# Altium schematic sheet Trap_PCB_V3.SchDoc
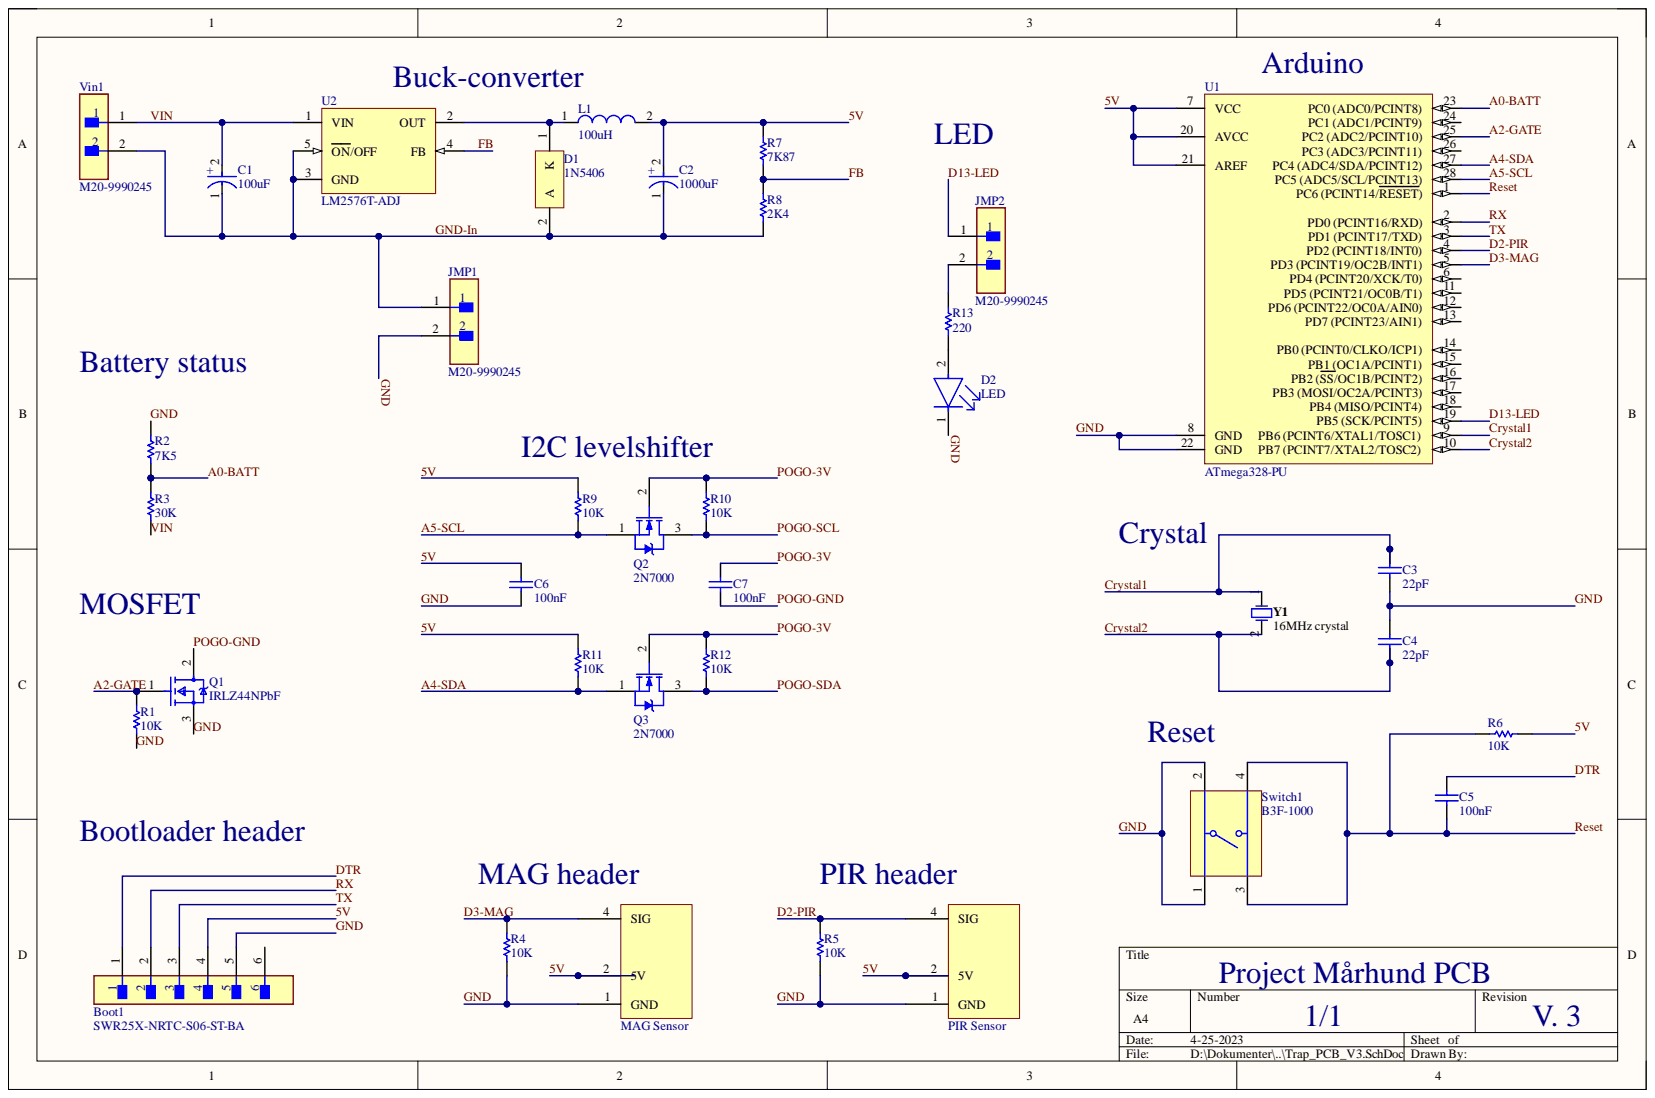
[diagram p0: Trap_PCB_V3.SchDoc]

## Parts
*  PIR Sensor  [PCBComponent_1 - duplicate2]  (value not drawn)
*?  MAG Sensor  [PCBComponent_1 - duplicate2]
Boot1  SWR25X-NRTC-S06-ST-BA  [FP-SWR25X-NRTC-S06-ST-BA-MFG]
C1  100uF  [FP-EEU-FR1H101B-MFG]
C2  1000uF  [FP-RAD-TH-D_10_0_5-L_12_5-MFG]
C3  22pF  [KEMT-C315-THT-2]
C4  22pF  [KEMT-C315-THT-2]
C5  100nF  [KEMT-C315-THT-2]
C6  100nF  [KEMT-C315-THT-2]
C7  100nF  [KEMT-C315-THT-2]
D1  1N5406  [ONSC-AXIAL_LEAD-2-267-05_V]
D2  LED  [FP-HLMP-1719-MFG]
JMP1  M20-9990245  [FP-M20-9990245-MFG]
JMP2  M20-9990245  [FP-M20-9990245-MFG]
L1  100uH  [WE-TI-LB]
Q1  IRLZ44NPbF  [FP-PG-TO220-3-MFG]
Q2  2N7000  [ONSC-TO-92-3-29-11]
Q3  2N7000  [ONSC-TO-92-3-29-11]
R1  10K  [OMTE-RES7X2.5-2]
R2  7K5  [OMTE-RES7X2.5-2]
R3  30K  [OMTE-RES7X2.5-2]
R4  10K  [OMTE-RES7X2.5-2]
R5  10K  [OMTE-RES7X2.5-2]
R6  10K  [OMTE-RES7X2.5-2]
R7  7K87  [OMTE-RES7X2.5-2]
R8  2K4  [OMTE-RES7X2.5-2]
R9  10K  [OMTE-RES7X2.5-2]
R10  10K  [OMTE-RES7X2.5-2]
R11  10K  [OMTE-RES7X2.5-2]
R12  10K  [OMTE-RES7X2.5-2]
R13  220  [OMTE-RES7X2.5-2]
Switch1  B3F-1000  [OMRON-B3F-1000-4_V]
U1  ATmega328-PU  [28P3]
U2  LM2576T-ADJ  [KC0005A]
Vin1  M20-9990245  [FP-M20-9990245-MFG]
Y1  16MHz crystal  [FOX-FOXSLF160-20]

## Nets
5V: *.2 *?.2 Boot1.4 C2.2 C6.2 L1.2 R6.1 R7.2 R9.1 R11.1 U1.7(VCC) U1.20(AVCC) U1.21(AREF)
A0-BATT: R2.1 R3.2 U1.23
A2-GATE: Q1.1 R1.2 U1.25
A4-SDA: Q3.1 R11.2 U1.27
A5-SCL: Q2.1 R9.2 U1.28(PC5_(ADC5/SCL/PCINT13))
Crystal1: C3.2 U1.9 Y1.1
Crystal2: C4.1 U1.10 Y1.2
D2-PIR: *.4 R5.2 U1.4(PD2_(PCINT18/INT0))
D3-MAG: *?.4 R4.2 U1.5(PD3_(PCINT19/OC2B/INT1))
D13-LED: JMP2.1 U1.19(PB5_(SCK/PCINT5))
DTR: Boot1.1 C5.2
FB: R7.1 R8.2 U2.4(FB)
GND: *.1 *?.1 Boot1.5 C3.1 C4.2 C6.1 D2.1 JMP1.2 Q1.3 R1.1 R2.2 R4.1 R5.1 Switch1.1 Switch1.2 U1.8(GND) U1.22(GND)
GND-In: C1.1 C2.1 D1.2 JMP1.1 R8.1 U2.3(GND) U2.5(ON/OFF) Vin1.2
POGO-3V: C7.1 Q2.2 Q3.2 R10.1 R12.1
POGO-GND: C7.2 Q1.2
POGO-SCL: Q2.3 R10.2
POGO-SDA: Q3.3 R12.2
Reset: C5.1 R6.2 Switch1.3 Switch1.4 U1.1(PC6_(PCINT14/RESET))
RX: Boot1.2 U1.2(PD0_(PCINT16/RXD))
TX: Boot1.3 U1.3(PD1_(PCINT17/TXD))
VIN: C1.2 R3.1 U2.1(VIN) Vin1.1
NetD1_1: D1.1 L1.1 U2.2(OUT)
NetD2_2: D2.2 R13.1
NetJMP2_2: JMP2.2 R13.2

Not connected: Boot1 6; U1 6(PD4_(PCINT20/XCK/T0)) 11(PD5_(PCINT21/OC0B/T1)) 12(PD6_(PCINT22/OC0A/AIN0)) 13(PD7_(PCINT23/AIN1)) 14(PB0_(PCINT0/CLKO/ICP1)) 15(PB1_(OC1A/PCINT1)) 16(PB2_(SS/OC1B/PCINT2)) 17(PB3_(MOSI/OC2A/PCINT3)) 18(PB4_(MISO/PCINT4)) 24(PC1_(ADC1/PCINT9)) 26(PC3_(ADC3/PCINT11))

## Library data of the parts
*? = PIR Sensor: Component Kind: Standard; Library Name: Trap_PCB_V2.SchLib
Boot1 = CMP-25680-000010-1: CONN HEADER VERT 6POS 2.54MM; Manufacturer: Sullins; Conn Gender: Male; Conn Orientation: Straight; Current Rating: 3A; Number Of Contacts: 6; Voltage Rating: 250V; Automotive: No; Category: Conn; Component Kind: Standard; ComponentLink2Description: Datasheet; ComponentLink2URL: https://drawings-pdf.s3.amazonaws.com/11633.pdf; Contact Material: Tin,Nickel; Device Class L1: Connectors; Device Class L2: Headers and Wire Housings; Device Class L3: unset; Digikey Description: CONN HEADER VERT 6POS 2.54MM; Height: 11.7mm; Lead Free: Yes; Library Name: Altium Content Vault; Manufacturer Part Number: SWR25X-NRTC-S06-ST-BA; Number Of Rows: 1; Octopart: https://octopart.com/swr25x-nrtc-s06-st-ba-sullins-20912730; Package: SWR25X-NRTC-S06-ST-BA; Pitch: 2.54mm; RoHS: TRUE; Temperature: -25°C to +85°C; Temperature Range High: +85°C; Temperature Range Low: -25°C
C1 = CMP-05427-000020-1: CAP ALUM 100UF 20% 50V RADIAL; ESR (Equivalent Series Resistance): 61mR; Lead Diameter: 0.6mm; Life (Hours): 6000Hour; Ripple Current: 870mA; Capacitance: 100uF; Case/Package: Radial; Component Kind: Standard; Contact Plating: Tin; Diameter: 8mm; Features: General Purpose; Height: 11.5mm; Height - Seated (Max): 0.512inch; Impedance: 61mR; Lead Free: Lead Free; Lead Pitch: 5mm; Length: 11.5mm; Library Name: Altium Content Vault; Max Operating Temperature: 105°C; Min Operating Temperature: -40°C; Mount: Through Hole; Package Quantity: 1; Packaging: Tape and Reel; Radiation Hardening: No; REACH SVHC: No SVHC; RoHS Compliant: Yes; Series: FR; Termination: Radial; Tolerance: 20%; Voltage Rating: 50V; Voltage Rating (DC): 50V
C2 = CMP-05427-001280-1: Aluminum Electrolytic Capacitors (Radial Lead Type) AEC-Q200 Qualified 1000uF ±20% 6.3V; Capacitance: 1mF; Dielectric Material: Aluminium; ESR (Equivalent Series Resistance): 90mR; Lead Diameter: 0.6mm; Leakage Current: 3uA; Life (Hours): 3000Hour; Polarity: Polar; Ripple Current: 755mA; Case/Package: Radial; Component Kind: Standard; Construction: RADIAL; Contact Plating: Tin; Diameter: 10mm; Height: 12.5mm; Height - Seated (Max): 0.492inch; Impedance: 90mR; Lead Free: Lead Free; Lead Pitch: 5mm; Length: 12.5mm; Library Name: Altium Content Vault; Max Operating Temperature: 105°C; Min Operating Temperature: -55°C; Mount: Through Hole; Number of Pins: 2; Package Quantity: 200; Packaging: Bulk; Radiation Hardening: No; Ratings: AEC-Q200; REACH SVHC: No SVHC; Ripple Current (AC): 755mA; RoHS Compliant: Yes; Series: FC; Size: 12.5mm; Termination: Radial; Tolerance: 20%; Voltage: 6.3V; Voltage Rating: 6.3V; Voltage Rating (DC): 6.3V
C3-C7 = CMP-1664-00008-1: Capacitor, Ceramic, 22 pF, +/-10 %, 100 V, -55 to 125 degC, 2-Pin THD, RoHS, Bulk; Case\Package: TO-220; Max Input Voltage: 40 V; Max Ouput Current: 1.5 A; Min Input Voltage: 4.2 V; Output Voltage: 1.2 V; Outputs: 1; Component Kind: Standard; ComponentLink1Description: Manufacturer URL; ComponentLink1URL: http://www.kemet.com/; ComponentLink2Description: Datasheet; ComponentLink2URL: http://capacitoredge.kemet.com/capedge2/DataSheet/Datasheet-C315C220K1G5TA.pdf?pn=C315C220K1G5TA{BULK}; DatasheetVersion: Aug-16; Height: 9.28 mm; Length: 10.28 mm; Library Name: Altium Content Vault; Max Operating Temperature: 125 degC; Min Operating Temperature: 0 degC; Mount: Through Hole; Output Current: 1.5 A; Package Quantity: 50; PackageDescription: 2-Pin Through Hole Device, Body 3.81 x 2.54 mm; PackageReference: C315-THT-2; Packaging: Rail/Tube; Pins: 3; Radiation Hardening: No; REACH SVHC: No SVHC; RoHSCompliant: true; Weight: 0.08113 oz; Width: 4.82 mm
D1 = CMP-0902-00090-1: Standard Recovery Rectifier, 2-Pin Axial_Lead, Pb-Free, Bulk Box; Manufacturer: On Semiconductor; IFSM Max (A): 200; IO(rec) Max (A): 3; VRRM Max (V): 600; Component Kind: Standard; ComponentLink1Description: Manufacturer URL; ComponentLink1URL: http://www.onsemi.com/; ComponentLink2Description: Datasheet; ComponentLink2URL: http://www.onsemi.com/pub_link/Collateral/1N5400-D.PDF; ComponentLink3Description: Package Specification; ComponentLink3URL: http://www.onsemi.com/pub_link/Collateral/267-05.PDF; DatasheetVersion: Rev. 10; Library Name: Altium Content Vault; Mounting Technology: Through Hole; PackageDescription: 2-Pin, Axial Lead, Diameter 5.05 mm; PackageReference: AXIAL_LEAD-2-267-05; PackageVersion: Rev. G, 06/2000; Packing: Bulk Box; PartNumber: 1N5406; RoHS: TRUE; Type: Standard Recovery
D2 = CMP-2000-06410-2: LED YELLOW DIFFUSED T-1 T/H; Manufacturer: Broadcom; Forward Current: 2mA; Forward Voltage: 1.8V; Led Orientation: Straight; Luminous Intensity: 2.1mcd; Power Dissipation: 17.5mW; Reverse Voltage: 5V; Viewing Angle: 50°; Wavelength: 585nm; Automotive: No; Category: Diode; Colour: Yellow; Component Kind: Standard; ComponentLink2Description: Datasheet; ComponentLink2URL: https://docs.broadcom.com/docs/AV02-1557EN; Device Class L1: Optoelectronics; Device Class L2: LEDs; Device Class L3: unset; Digikey Description: LED YELLOW DIFFUSED T-1 T/H; Lead Free: Yes; Library Name: Altium Content Vault; Manufacturer Part Number: HLMP-1719; Mouser Description: Standard LEDs - Through Hole Yellow Diffused 585nm 2.1mcd; Octopart: https://octopart.com/hlmp-1719-broadcom-71130405; Package: 0; RoHS: TRUE; Temperature: -40°C to +100°C; Temperature Range High: +100°C; Temperature Range Low: -40°C
JMP1 JMP2 Vin1 = CMP-15831-000105-1: CONN HEADER VERT 2POS 2.54MM; Connector Type: Male Pin; Contact Resistance: 25mR; Current: 3A; Gender: Male; Insulation Resistance: 10GR; Mated Stacking Heights: 16.54mm; Mating Cycles: 300; Number of Contacts: 2; Voltage: 50V; Voltage Rating (AC): 750V; Case/Package: SIL; Color: Black; Component Kind: Standard; Contact Finish - Mating: GOLD; Contact Material: Brass; Contact Mating Length: 0.24inch; Contact Plating: Gold; Contact Shape: Square; Contact Type: Male Pin; Current Rating: 3A; Depth: 2.54mm; Fastening Type: Pull; Flammability Rating: UL94 V-0; Height: 0.12inch; Housing Color: Black; Housing Material: Nylon; Insulation Color: Black; Insulation Material: Nylon; Lead Pitch: 2.54mm; Length: 5.08mm; Library Name: Altium Content Vault; Max Current Rating: 3A; Max Operating Temperature: 105°C; Min Operating Temperature: -40°C; Mount: Through Hole; Number of Poles: 2; Number of Positions: 2; Number of Rows: 1; Orientation: Straight; Package Quantity: 1000; Packaging: Bulk; Pitch: 2.54mm; Radiation Hardening: No; Reach SVHC Compliant: Yes; Series: M20; Termination: Solder; Weight: 0.25lb; Width: 0.25inch; Working Voltage: 750V
L1 = CMP-0225-00065-1: Radial Leaded Wire Wound Inductor WE-TI, L = 100 µH; Inductance: 100 ÂµH; Component Kind: Standard; ComponentLink1Description: Manufacturer Link; ComponentLink1URL: http://www.we-online.com; ComponentLink2Description: Datasheet; ComponentLink2URL: http://www.we-online.de/katalog/media/pdf/744743101.pdf; DatasheetVersion: ver 3, Nov-2004; Library Name: Altium Content Vault; PackageDescription: THT Inductor, 2-Leads, Body 8.0 x 8.0 mm; PackageReference: WE-TI-LB; PackageVersion: 2004
Q1 = CMP-32901-000488-1: MOSFET N-CH 55V 47A TO220AB; Drain to Source Resistance: 35mR; Element Configuration: Single; Gate to Source Voltage (Vgs): 16V; Input Capacitance: 1.7nF; Max Power Dissipation: 110W; Rds On Max: 22mR; Threshold Voltage: 2V; Turn-Off Delay Time: 26ns; Turn-On Delay Time: 11ns; Case/Package: TO-220; Component Kind: Standard; Continuous Drain Current (ID): 47A; Drain to Source Breakdown Voltage: 55V; Drain to Source Voltage (Vdss): 55V; Halogen Free: Halogen Free; Height: 0.6inch; Lead Free: Lead Free; Length: 0.414inch; Library Name: Altium Content Vault; Max Dual Supply Voltage: 55V; Max Operating Temperature: 175°C; Min Operating Temperature: -55°C; Mount: Through Hole; Number of Pins: 3; Packaging: Rail/Tube; Power Dissipation: 110W; REACH SVHC: No SVHC; RoHS Compliant: Yes; Width: 0.185inch
Q2 Q3 = CMP-1058-00936-1: Small Signal MOSFET, 200 mA, 60 V, N-Channel, 3-Pin TO-92, Bulk Box; Manufacturer: On Semiconductor; Channel Polarity: N Channel; Ciss Typ (pF): 60; Configuration: Single; Coss Typ (pF): 25; Crss Typ (pF): 5; ID Max (A): 0.2; PD Max (W): 0.35; VGS Max (V): 20; VGS(th) Max (V): 3; Component Kind: Standard; ComponentLink1Description: Manufacturer URL; ComponentLink1URL: http://www.onsemi.com/; ComponentLink2Description: Datasheet; ComponentLink2URL: http://www.onsemi.com/pub_link/Collateral/2N7000-D.PDF; ComponentLink3Description: Package Specification; ComponentLink3URL: http://www.onsemi.cn/pub_link/Collateral/2N6426-D.PDF; DatasheetVersion: Rev. 8; Library Name: Altium Content Vault; Mounting Technology: Through Hole; Package Type: TO-92; PackageDescription: 3-Pin Transistor Outline, Body 4.825 x 3.685 mm, Pitch 1.27 mm; PackageReference: TO-92-3-29-11_AM_SL; PackageVersion: Rev. AM; Packing: Bulk Box; PartNumber: 2N7000; V(BR)DSS Min (V): 60
R1 R4-R6 R9-R12 = CMP-2000-06865-1: Little Demon(R) Carbon Composition Molded OD Series, 250 V, 10 KOhm, +/-5%, -70 to 130 degC, 2-Pin THD, RoHS, Bulk; Manufacturer: Ohmite; PartNumber: OD103JE; Catalog Drawings: OD,OF,OASeries; EDA / CAD Models: DownloadfromAcceleratedDesigns; Online Catalog: OD,OF,OASeries,LittleDemon®; Power (Watts): 0.25W,1/4W; Power Rating: 0.25W; Tolerance: ±5%; Category: Resistors; Component Kind: Standard; Composition: CarbonComposition; Datasheet URL: http://www.ohmite.com/cat/res_od_of_oa.pdf; Datasheet Version: 06/2016; Family: ThroughHoleResistors; Features: PulseWithstanding; Height: -; Library Name: Altium Content Vault; Manufacturer URL: http://www.ohmite.com/; Mounting Technology: ThroughHole; Number of Terminations: 2; Operating Temperature: -; Package / Case: Axial; Package Description: 2-Pin THD Resistor, Body 7 x 2.5 mm, Lead Dia. 0.6 mm; Package Reference: RES7X2.5; Packaging: Bulk; Resistance (Ohms): 10k; RoHS Information: LittleDemon"E"SeriesMaterialDeclaration; Series: LittleDemon®OD; Size / Dimension: 0.098"Diax0.276"L(2.50mmx7.00mm); Standard Package: 100; Supplier Device Package: Axial; Temperature Coefficient: -
R2 R3 R7 R8 R13 = CMP-2000-06865-1: Little Demon(R) Carbon Composition Molded OD Series, 250 V, 10 KOhm, +/-5%, -70 to 130 degC, 2-Pin THD, RoHS, Bulk; Manufacturer: Ohmite; PartNumber: OD103JE; Catalog Drawings: OD,OF,OASeries; EDA / CAD Models: DownloadfromAcceleratedDesigns; Online Catalog: OD,OF,OASeries,LittleDemon®; Power (Watts): 0.25W,1/4W; Power Rating: 0.25W; Resistance (Ohms): 10k; Tolerance: ±5%; Category: Resistors; Component Kind: Standard; Composition: CarbonComposition; Datasheet URL: http://www.ohmite.com/cat/res_od_of_oa.pdf; Datasheet Version: 06/2016; Family: ThroughHoleResistors; Features: PulseWithstanding; Height: -; Library Name: Altium Content Vault; Manufacturer URL: http://www.ohmite.com/; Mounting Technology: ThroughHole; Number of Terminations: 2; Operating Temperature: -; Package / Case: Axial; Package Description: 2-Pin THD Resistor, Body 7 x 2.5 mm, Lead Dia. 0.6 mm; Package Reference: RES7X2.5; Packaging: Bulk; RoHS Information: LittleDemon"E"SeriesMaterialDeclaration; Series: LittleDemon®OD; Size / Dimension: 0.098"Diax0.276"L(2.50mmx7.00mm); Standard Package: 100; Supplier Device Package: Axial; Temperature Coefficient: -
Switch1 = CMP-1677-00002-1: Tactile Switch, SPST-NO, 0.05 A, 5 to 24 V, -25 to 70 degC, 4-Pin THD, RoHS, Bulk; Capacitance: -45 f; Current Rating: 1.5 A; Gender: Female; Shielding: Shielded; Voltage Rating: 150 V; Component Kind: Standard; ComponentLink1Description: Manufacturer URL; ComponentLink1URL: http://www.omron.com/; ComponentLink2Description: Datasheet; ComponentLink2URL: http://www.omron.com/ecb/products/pdf/en-b3f.pdf; Contact Material: Brass; Contact Plating: Gold; DatasheetVersion: Jan-15; Height: 14.99 mm; Lead Pitch: 1.27 mm; Length: 18.34 mm; Library Name: Altium Content Vault; Max Operating Temperature: 70 degC; Min Operating Temperature: -40 degC; Mount: Through Hole; Package Quantity: 1080; PackageDescription: 4-Pin Through Hole Device, Body 6 x 6 mm; PackageReference: B3F-1000; Packaging: Tray; Pins: 8; Radiation Hardening: No; RoHSCompliant: true; Width: 25.4 mm
U1 = CMP-0095-00015-2: 8-bit AVR Microcontroller, 32KB Flash, 1KB EEPROM, 2KB SRAM, 28-pin PDIP, Industrial Grade (-40°C to 85°C); Component Kind: Standard; ComponentLink1Description: Manufacturer URL; ComponentLink1URL: http://www.atmel.com/; ComponentLink2Description: ATmega48A/48PA/88A/88PA/168A/168PA/328/328P Datasheet; ComponentLink2URL: http://www.atmel.com/dyn/resources/prod_documents/8271.pdf; ComponentLink3Description: ATmega48A/48PA/88A/88PA/168A/168PA/328/328P Summary; ComponentLink3URL: http://www.atmel.com/dyn/resources/prod_documents/8271S.pdf; DatasheetVersion: revD, May-2011; Library Name: Altium Content Vault; PackageDescription: 28-lead (0.300"/7.62mm Wide) Plastic Dual Inline Package (PDIP); PackageReference: 28P3; PackageVersion: revB, Sep-2001; RevisionNote: DatasheetVersion updated. URLs added.
U2 = CMP-0069-02003-3: SIMPLE SWITCHER® 3A Step-Down Voltage Regulator, 5-pin TO-220; Manufacturer: Texas Instruments; PackageTopMarking: NSUZXYTTE#*LM2576T*-ADJ P+; PowerWise: N; Code_JEDEC: TO-220-AB; Component Kind: Standard; ComponentLink1Description: Datasheet URL; ComponentLink1URL: http://www.ti.com/general/docs/lit/getliterature.tsp?genericPartNumber=LM2576&fileType=pdf; CompType: Switcher; Library Name: Altium Content Vault; PackageDescription: TO-220, Molded, 5 Lead, Straight; PackageReference: KC0005A; PinCount: 5; RoHS: N
Y1 = CMP-1749-00003-1: Resistance Weld Thru-Hole Crystal, 16 MHz, -20 to 70 degC, 2-Pin THD, RoHS, Bulk; Case\Package: Axial; Power Rating: 250 mW; Resistance: 221 kohm; Tolerance: 1%; Voltage Rating: 250 V; Component Kind: Standard; ComponentLink1Description: Manufacturer URL; ComponentLink1URL: http://www.foxonline.com/; ComponentLink2Description: Datasheet; ComponentLink2URL: http://www.foxonline.com/pdfs/hc49slf.pdf; DatasheetVersion: Mar-15; Length: 0.248 inch; Library Name: Altium Content Vault; Max Operating Temperature: 155 degC; Min Operating Temperature: -55 degC; Mount: Through Hole; Package Quantity: 200; PackageDescription: 2-Pin Through Hole Device, Body 11.35 x 4.65 mm; PackageReference: FOXSLF160-20; Packaging: Bulk; RoHSCompliant: true
* = PIR Sensor: Component Kind: Standard; Library Name: Trap_PCB_V2.SchLib
